# Altium schematic sheet Sheet1.SchDoc(Sheet1)
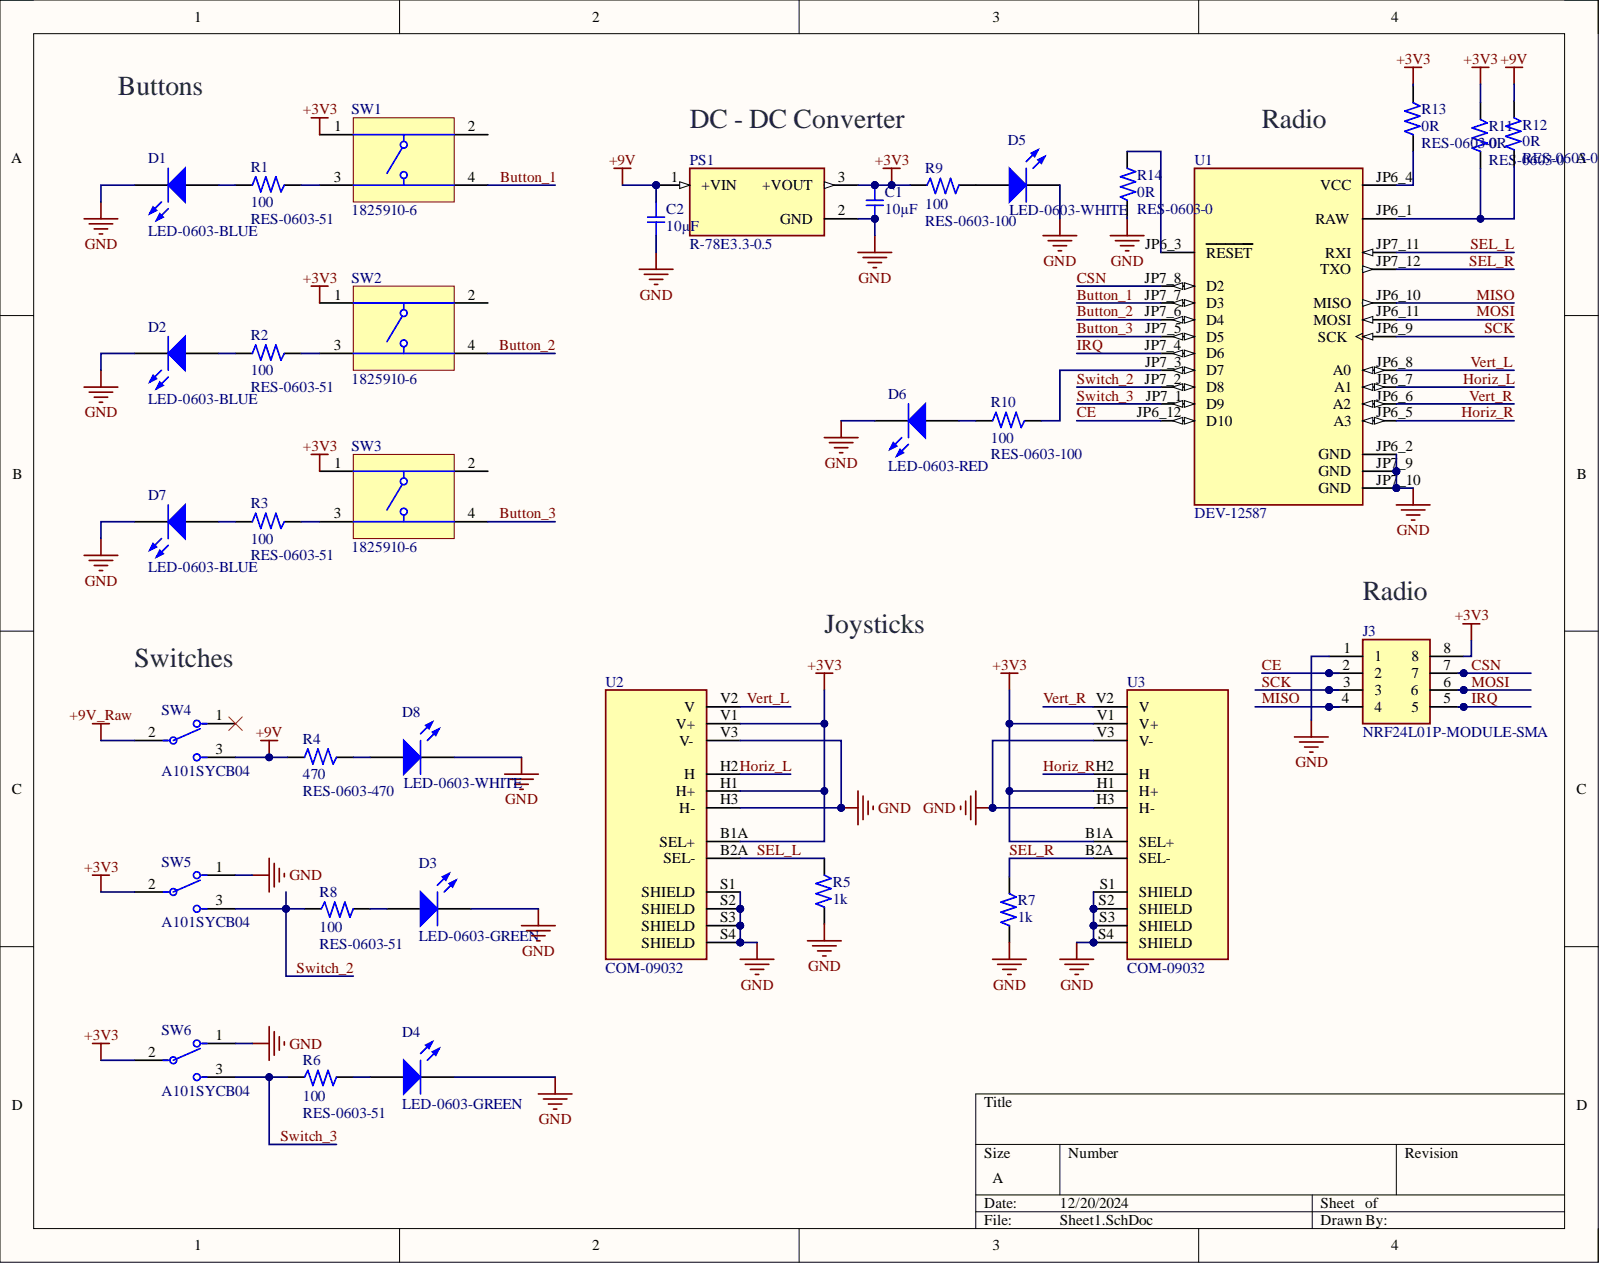
[diagram p0: Sheet1.SchDoc]

## Parts
C1  value 10µF  (hidden comment: GRT188R61C106KE13D)
C2  value 10µF  (hidden comment: GRT188R61C106KE13D)
D1  LED-0603-BLUE
D2  LED-0603-BLUE
D3  LED-0603-GREEN
D4  LED-0603-GREEN
D5  LED-0603-WHITE
D6  LED-0603-RED
D7  LED-0603-BLUE
D8  LED-0603-WHITE
J3  NRF24L01P-MODULE-SMA
PS1  R-78E3.3-0.5
R1  RES-0603-51  value 100
R2  RES-0603-51  value 100
R3  RES-0603-51  value 100
R4  RES-0603-470  value 470
R5  value 1k  (hidden comment: RES-0603-1k)
R6  RES-0603-51  value 100
R7  value 1k  (hidden comment: RES-0603-1k)
R8  RES-0603-51  value 100
R9  RES-0603-100  value 100
R10  RES-0603-100  value 100
R11  RES-0603-0
R12  RES-0603-0  value 0R
R13  RES-0603-0  value 0R
R14  RES-0603-0  value 0R
SW1  1825910-6
SW2  1825910-6
SW3  1825910-6
SW4  A101SYCB04
SW5  A101SYCB04
SW6  A101SYCB04
U1  DEV-12587
U2  COM-09032
U3  COM-09032

## Nets
+3V3: C1.1 J3.8 PS1.3 R9.2 R11.2 R13.2 SW1.1 SW2.1 SW3.1 SW5.2 SW6.2 U2.B1A(SEL+) U2.H1(H+) U2.V1(V+) U3.B1A(SEL+) U3.H1(H+) U3.V1(V+)
+9V: C2.1 PS1.1 R4.1 R12.2 SW4.3
+9V_Raw: SW4.2
GND: C1.2 C2.2 D1.2 D2.2 D3.2 D4.2 D5.2 D6.2 D7.2 D8.2 J3.1 PS1.2 R5.1 R7.1 R14.2 SW5.1 SW6.1 U1.JP6_2(GND) U1.JP7_9(GND) U1.JP7_10(GND) U2.H3(H-) U2.S1(SHIELD) U2.S2(SHIELD) U2.S3(SHIELD) U2.S4(SHIELD) U2.V3(V-) U3.H3(H-) U3.S1(SHIELD) U3.S2(SHIELD) U3.S3(SHIELD) U3.S4(SHIELD) U3.V3(V-)
Button_1: SW1.4 U1.JP7_7(D3)
Button_2: SW2.4 U1.JP7_6(D4)
Button_3: SW3.4 U1.JP7_5(D5)
CE: J3.2 U1.JP6_12(D10)
CSN: J3.7 U1.JP7_8(D2)
Horiz_L: U1.JP6_7(A1) U2.H2(H)
Horiz_R: U1.JP6_5(A3) U3.H2(H)
IRQ: J3.5 U1.JP7_4(D6)
MISO: J3.4 U1.JP6_10(MISO)
MOSI: J3.6 U1.JP6_11(MOSI)
SCK: J3.3 U1.JP6_9(SCK)
SEL_L: R5.2 U1.JP7_11(RXI) U2.B2A(SEL-)
SEL_R: R7.2 U1.JP7_12(TXO) U3.B2A(SEL-)
Switch_2: R8.2 SW5.3 U1.JP7_2(D8)
Switch_3: R6.2 SW6.3 U1.JP7_1(D9)
Vert_L: U1.JP6_8(A0) U2.V2(V)
Vert_R: U1.JP6_6(A2) U3.V2(V)
NetD1_1: D1.1 R1.1
NetD2_1: D2.1 R2.1
NetD3_1: D3.1 R8.1
NetD4_1: D4.1 R6.1
NetD5_1: D5.1 R9.1
NetD6_1: D6.1 R10.1
NetD7_1: D7.1 R3.1
NetD8_1: D8.1 R4.2
NetR1_2: R1.2 SW1.3
NetR2_2: R2.2 SW2.3
NetR3_2: R3.2 SW3.3
NetR10_2: R10.2 U1.JP7_3(D7)
NetR11_1: R11.1 R12.1 U1.JP6_1(RAW)
NetR13_1: R13.1 U1.JP6_4(VCC)
NetR14_1: R14.1 U1.JP6_3(RESET)

Not connected: SW1 2; SW2 2; SW3 2; SW4 1

## Library data of the parts
C1 C2 = CMP-292-000020-1: CAP CER 10UF 16V X5R 0603; Manufacturer: Murata Electronics North America; @ qty: 0; Minimum Quantity: 1; Tolerance: 10%; Unit Price (USD): 0.44; Applications: Automotive; Component Kind: Standard; Datasheets: http://search.murata.co.jp/Ceramy/image/img/A01X/G101/ENG/GRT188R61C106KE13-01.pdf; Design Item ID: GRT188R61C106KE13D; Digi-Key Part Number: 490-12317-1-ND; Factory Stock: 0; Features: -; Height - Seated (Max): -; Image: //media.digikey.com/Renders/Murata%20Renders/0603%28LQG18%29.jpg; Lead Spacing: -; Lead Style: -; Mounting Type: Surface Mount, MLCC; Operating Temperature: -55°C ~ 85°C; Package / Case: 0603 (1608 Metric); Packaging: Cut Tape (CT); Part Number: GRT188R61C106KE13D; Part Status: Active; Quantity Available: 19486; Ratings: AEC-Q200; Series: GRT; Size / Dimension: 0.063" L x 0.031" W (1.60mm x 0.80mm); Source: PV Buck v2.SCHLIB; Temperature Coefficient: X5R; Thickness (Max): 0.035" (0.90mm); Voltage: 16V; Library Name: Formula Electric at Berkeley
D1 D4-D8 = CMP-004-00001-4: Green 0603 SMD LED; Manufacturer Product Number: LTST-C190KGKT; Component Kind: Standard; Component Type: Standard; Design Item ID: LED-0603; Digi-Key Part Number: 160-1435-1-ND; JLCPCB Part Number: C125094; JLCPCB Part Type: Extended; Mouser Part Number: 859-LTST-C190KGKT; Pin Count: 2; Source: LED0603.SchLib; Library Name: Formula Electric at Berkeley
D2 D3 = CMP-004-00000-4: Red 0603 SMD LED; Manufacturer Product Number: LTST-C190KRKT; Component Kind: Standard; Component Type: Standard; Design Item ID: LED-0603; Digi-Key Part Number: 160-1436-1-ND; JLCPCB Part Number: C125099; JLCPCB Part Type: Extended; Mouser Part Number: 859-LTST-C190KRKT; Pin Count: 2; Source: LED0603.SchLib; Library Name: Formula Electric at Berkeley
J3 = NRF24L01P-MODULE-SMA: Connector; Manufacturer_Name: Nordic Semiconductor; Arrow Part Number: NRF24L01P-MODULE-SMA; Arrow Price/Stock: https://www.arrow.com/en/products/nrf24l01p-module-sma/nordic-semiconductor?region=nac; Component Kind: Standard; Datasheet Link: https://infocenter.nordicsemi.com/pdf/nRF24L01P_PS_v1.0.pdf; Height: 4mm; Manufacturer_Part_Number: NRF24L01P-MODULE-SMA; Mouser Part Number: 949-NRF24L01P-MODSMA; Mouser Price/Stock: https://www.mouser.co.uk/ProductDetail/Nordic-Semiconductor/nRF24L01P-MODULE-SMA?qs=yd0qOhnSiDftbZo%2FB8rkYg%3D%3D
PS1 = CMP-397-000194-1: 0.5A DC/DC-Converter 'INNOLINE' SIP3 reg; MF: Recom Power; Check_prices: https://www.snapeda.com/parts/R-78E3.3-0.5/Recom+Power/view-part/?ref=eda; Component Kind: Standard; Component Type: Standard; Design Item ID: R-78E3.3-0.5; Digi-Key_Part_Number: 945-1661-5-ND; MP: R-78E3.3-0.5; Package: SIP-3 Recom Power; Pin Count: 3; Purchase-URL: https://pricing.snapeda.com/search/part/R-78E3.3-0.5/?ref=eda; SnapEDA_Link: https://www.snapeda.com/parts/R-78E3.3-0.5/Recom+Power/view-part/?ref=snap; Source: R-78E3.3-0.5.SchLib; Library Name: Formula Electric at Berkeley
R1-R3 R6 R8 = CMP-009-00028-2: 51Ohm 0603 Resistor; Manufacturer Product Number: RC0603FR-0751RL; Component Kind: Standard; Digi-Key Part Number: 311-51.0HRCT-ND; JLCPCB Part Number: C23197; Mouser Part Number: 603-RC0603FR-0751RL; Source: RES0603.SchLib; Library Name: Formula Electric at Berkeley
R4 = CMP-397-000109-1: 0603 470Ohm Resistor; Power: XW; Tolerance: X%; Component Kind: Standard; JLCPCB Part Type: Basic/Extended; Package: 0603; Source: RES0603.SchLib; Library Name: Formula Electric at Berkeley
R5 R7 = CMP-009-00012-2: 0603 1kOhm Resistor; Manufacturer Product Number: RC1608F102CS; Tolerance: 1%; Voltage Rating: 50V; Component Kind: Standard; Digi-Key Part Number: 1276-3484-1-ND; JLCPCB Part Number: C21190; Source: RES0603.SchLib; Library Name: Formula Electric at Berkeley
R9 R10 = CMP-009-00014-4: RES 100 OHM 1% 1/10W 0603; Manufacturer Product Number: RC0603FR-13100RL; Component Kind: Standard; Digi-Key Part Number: 13-RC0603FR-13100RLCT-ND; JLCPCB Part Number: C22775; Mouser Part Number: 603-RC0603FR-13100RL; Power: 1/10W; Source: RES0603.SchLib; Tolerance: 1%; Library Name: Formula Electric at Berkeley
R11 = CMP-009-00025-2: Metal Glaze/thick Film, 0.1W, 0ohm, Surface Mount, 0603; Manufacturer Product Number: RC0603JR-070RL; MP: 3-2176326-0; Package Height: 0.45mm; Package Length: 1.6mm; Package Width: 0.8mm; Power: 100mW; Rated Temperature: 70°C; Value: 0R; Availability: Unavailable; Case/Package: 0603; Component Kind: Standard; Construction: Chip; Design Item ID: 3-2176326-0; JESD-609 Code: e3; JLCPCB Part Number: C95177; Max Operating Temperature: 155°C; Min Operating Temperature: -55°C; Mounting Technology: Surface Mount; Package: None; Package Shape: Rectangular; Package Style: SMTMeter; Packaging: Tape and Reel; Pins: 2; Price: None; Reach SVHC Compliant: Yes; Resistor Type: FIXED RESISTOR; RoHS Compliant: Yes; Source: RES0603.SchLib; Technology: METAL GLAZE/THICK FILM; Terminal Finish: Nickel; Termination: Wraparound; Library Name: Formula Electric at Berkeley
R12-R14 = CMP-009-00025-2: Metal Glaze/thick Film, 0.1W, 0ohm, Surface Mount, 0603; Manufacturer Product Number: RC0603JR-070RL; MP: 3-2176326-0; Package Height: 0.45mm; Package Length: 1.6mm; Package Width: 0.8mm; Power: 100mW; Rated Temperature: 70°C; Availability: Unavailable; Case/Package: 0603; Component Kind: Standard; Construction: Chip; Design Item ID: 3-2176326-0; JESD-609 Code: e3; JLCPCB Part Number: C95177; Max Operating Temperature: 155°C; Min Operating Temperature: -55°C; Mounting Technology: Surface Mount; Package: None; Package Shape: Rectangular; Package Style: SMTMeter; Packaging: Tape and Reel; Pins: 2; Price: None; Reach SVHC Compliant: Yes; Resistor Type: FIXED RESISTOR; RoHS Compliant: Yes; Source: RES0603.SchLib; Technology: METAL GLAZE/THICK FILM; Terminal Finish: Nickel; Termination: Wraparound; Library Name: Formula Electric at Berkeley
SW1-SW3 = CMP-1684-00021-1: Tact Switch, SPST-NO, 0.05 A, -35 to 85 degC, 4-Pin THD, RoHS, Bulk; Manufacturer: TE Connectivity; Actuator Color: Black; Actuator Length: 1.4mm; Actuator Material: PolybutyleneTerephthalate; Actuator Style: RoundButton; Body Orientation: Straight; Bushing Type: Unthreaded; Contact Rating @ Voltage: 0.05A@24VDC; Dielectric Strength: 500VAC; Electrical Life: 100000Cycles; Function: MomentaryContact; Illumination: N/R; Maximum Contact Resistance: 100mOhm; Maximum DC Voltage Rating: 24V; Pole Throw Configuration: SPST; Switch Configuration: OFF(ON); Base Material: N/A; Component Kind: Standard; Contact Material: CopperAlloy; Contact Plating: Silver; Datasheet URL: http://www.te.com/commerce/DocumentDelivery/DDEController?Action=showdoc&DocId=Customer+Drawing%7F1825910%7FC9%7Fpdf%7FEnglish%7FENG_CD_1825910_C9.pdf%7F1825910-6; Datasheet Version: Rev. C9; Dimension: 5.99x5mm; Family: 1825910; Housing Material: N/A; Library Name: Altium Content Vault; Manufacturer URL: http://www.te.com; Maximum AC Voltage Rating: N/A; Mechanical Life: 100000Cycles; Minimum Insulation Resistance: 100MOhm; Mounting: ThroughHole; Operating Temperature: -35to85C; Package Description: 4-Pin Through Hole Device, Body 5.99 x 5.99 mm, Pitch 6.5 mm; Package Reference: THD; Packaging: N/A; Part No: 1825910-6; Product Weight: N/A; RoHS: Yes; Seal Description: N/A; Seal Location: N/A; Terminal Type: PCPins; Thread Size: N/R
SW4-SW6 = CMP-03408-000017-1: SWITCH TOGGLE SPDT 0.4VA 20V; Actuator Color: Chrome; Actuator Length: 10.41mm; Actuator Material: Phenolic; Actuator Type: Standard Round; Contact Current Rating: 400mA; Contact Resistance: 10mR; Electrical Life: 6000Cycles; Illumination Type: Non-Illuminated; Insulation Resistance: 30MR; Number of Poles: 1; Number of Positions: 2; Power Rating: 400mW; Switch Function: On-On; Component Kind: Standard; Contact Material: Copper; Contact Plating: Gold; Depth: 6.86mm; ELV: Compliant; Flammability Rating: UL94 V-1; Lead Free: Lead Free; Library Name: Altium Content Vault; Max Operating Temperature: 85°C; Max Voltage Rating (AC): 20V; Max Voltage Rating (DC): 20V; Min Operating Temperature: -30°C; Mount: Panel; Orientation: Straight; Package Quantity: 100; Packaging: Bulk; Radiation Hardening: No; REACH SVHC: No SVHC; RoHS Compliant: Yes; Series: Gemini A; Termination: PC Pins; Throw Configuration: SPDT; Voltage Rating (AC): 20V; Voltage Rating (DC): 20V
U1 = CMP-680-000000-1: ATmega32U4 Pro Micro AVRÂ® ATmega AVR MCU 8-Bit Embedded Evaluation Board; MF: SparkFun Electronics; Check_prices: https://www.snapeda.com/parts/DEV-12587/SparkFun+Electronics/view-part/?ref=eda; Component Kind: Standard; Component Type: Standard; Design Item ID: DEV-12587; MP: DEV-12587; Package: None; Pin Count: 24; SnapEDA_Link: https://www.snapeda.com/parts/DEV-12587/SparkFun+Electronics/view-part/?ref=snap; Source: DEV-12587.SchLib; Library Name: Formula Electric at Berkeley
U2 U3 = CMP-688-000000-1: Thumb Joystick; Availability: Unavailable; Component Kind: Standard; Component Type: Standard; Design Item ID: COM-09032; MP: COM-09032; Package: None; Pin Count: 12; Price: None; Source: COM-09032.SchLib; Library Name: Formula Electric at Berkeley
